# Altium schematic sheet INTERFACE.SchDoc(INTERFACE)
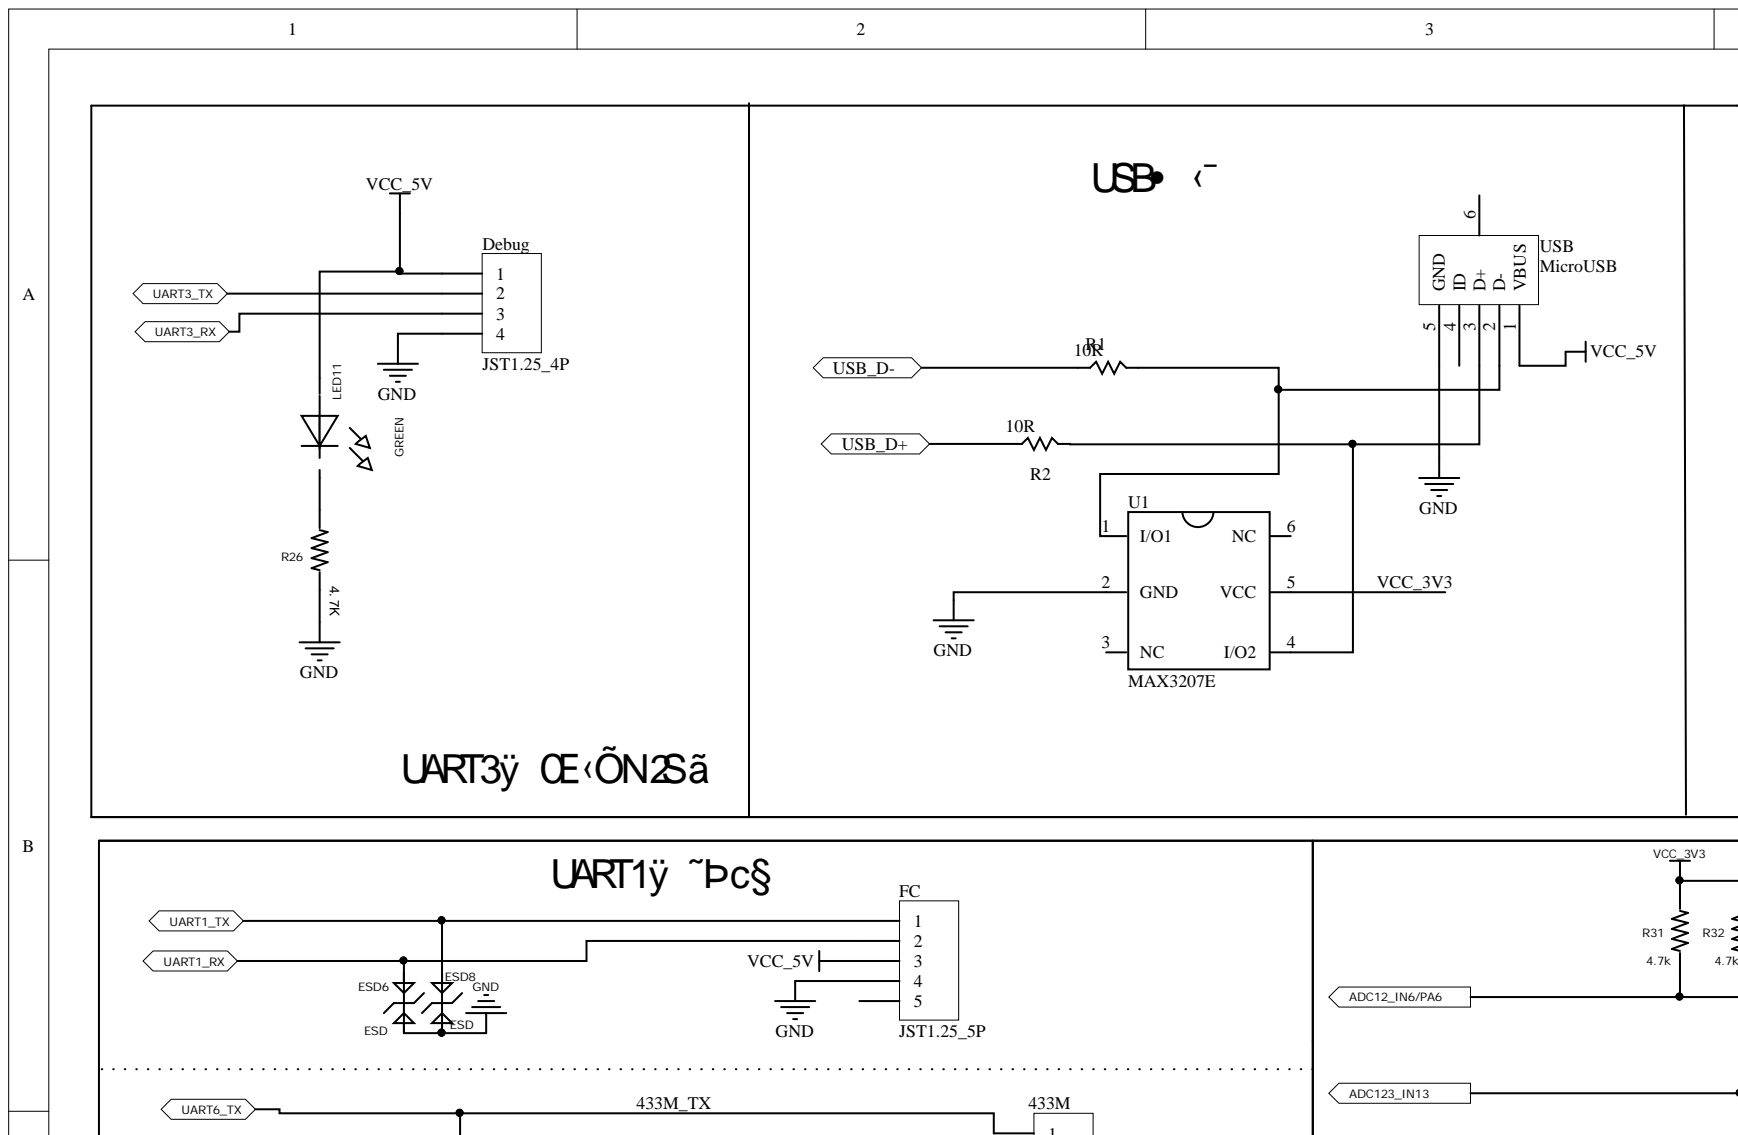
[diagram p0: INTERFACE.SchDoc, top left]
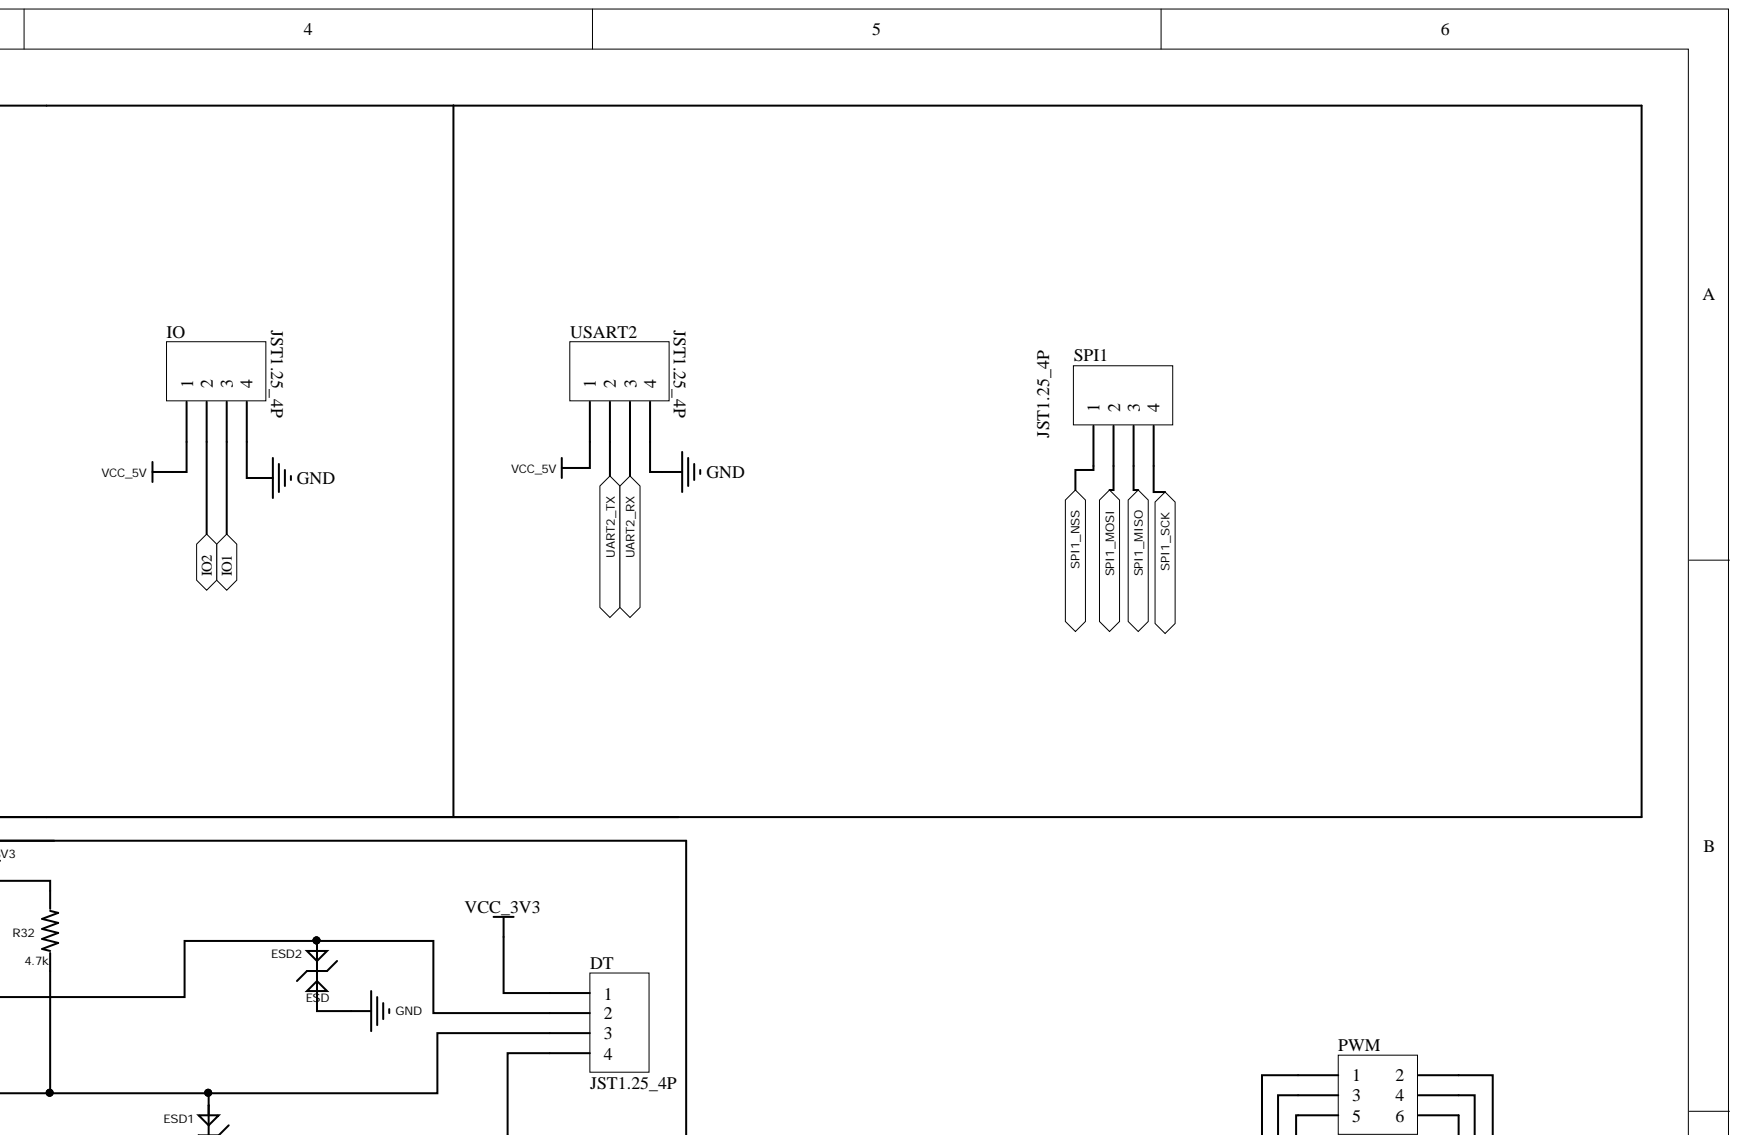
[diagram p1: INTERFACE.SchDoc, top right]
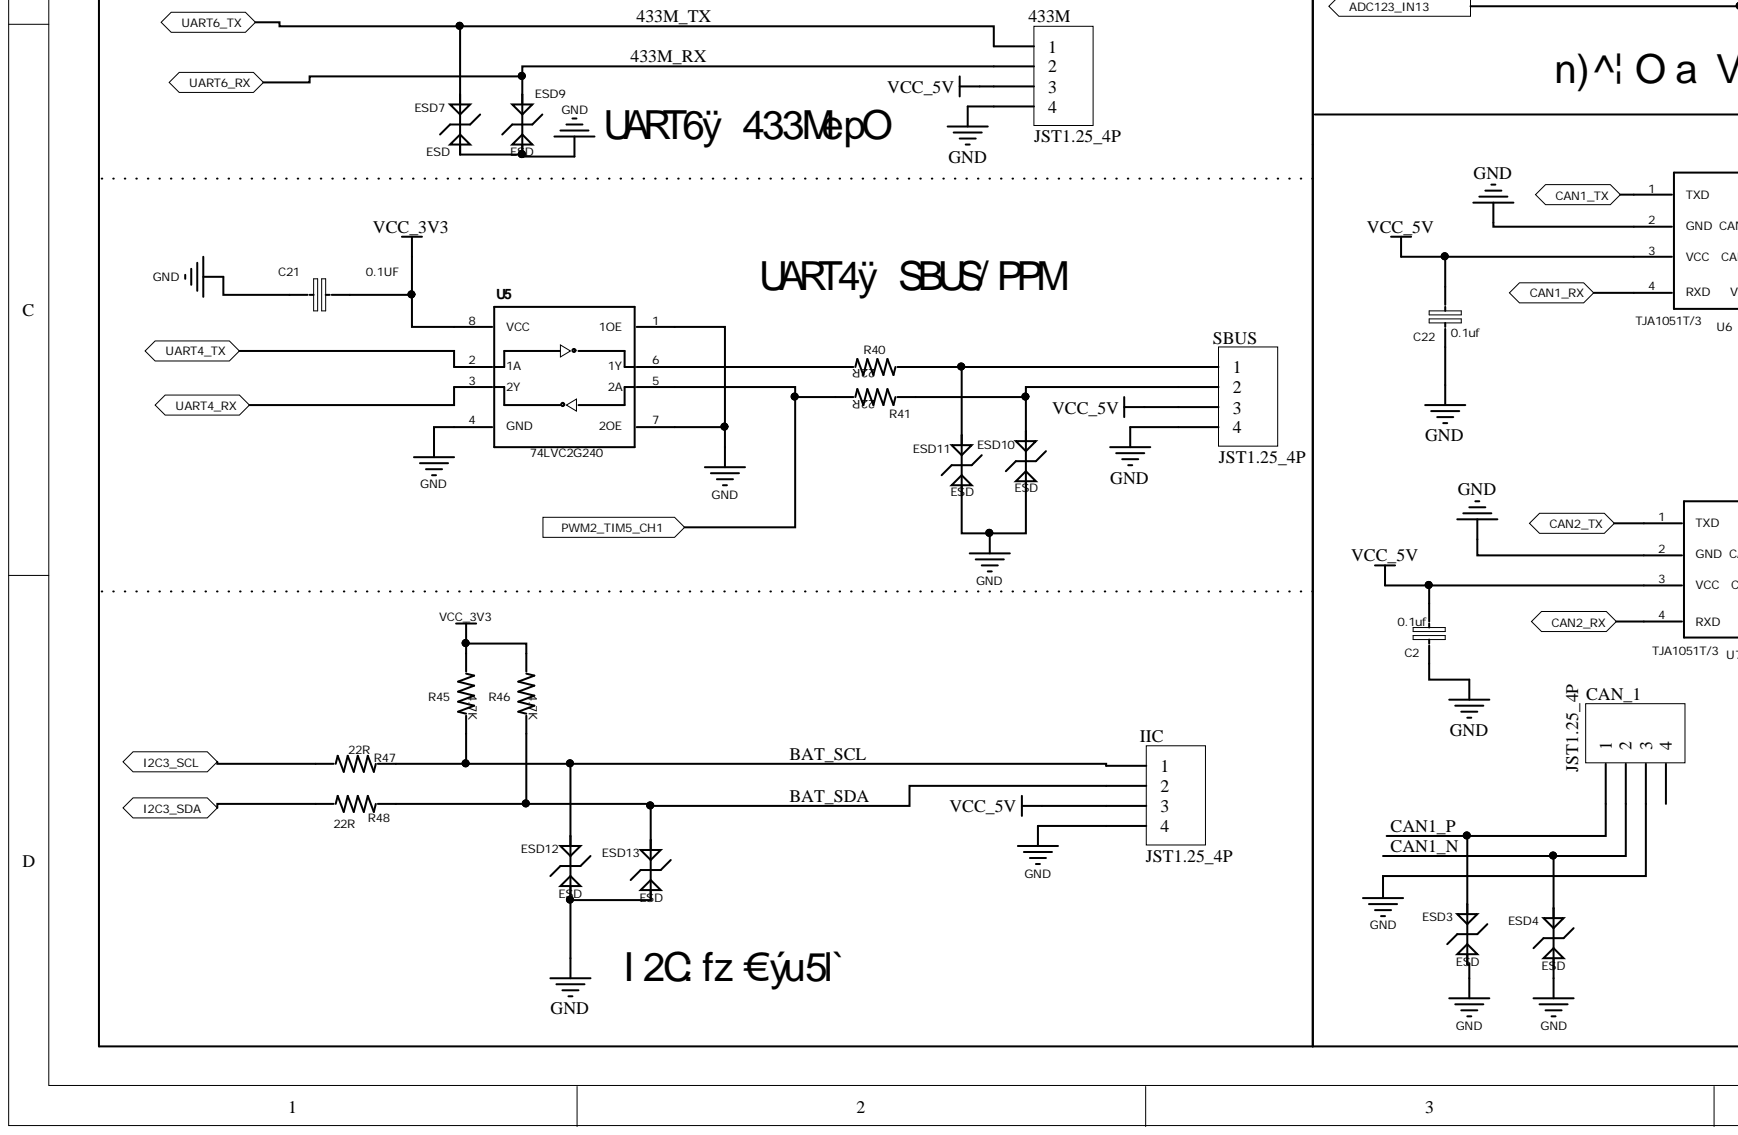
[diagram p2: INTERFACE.SchDoc, bottom left]
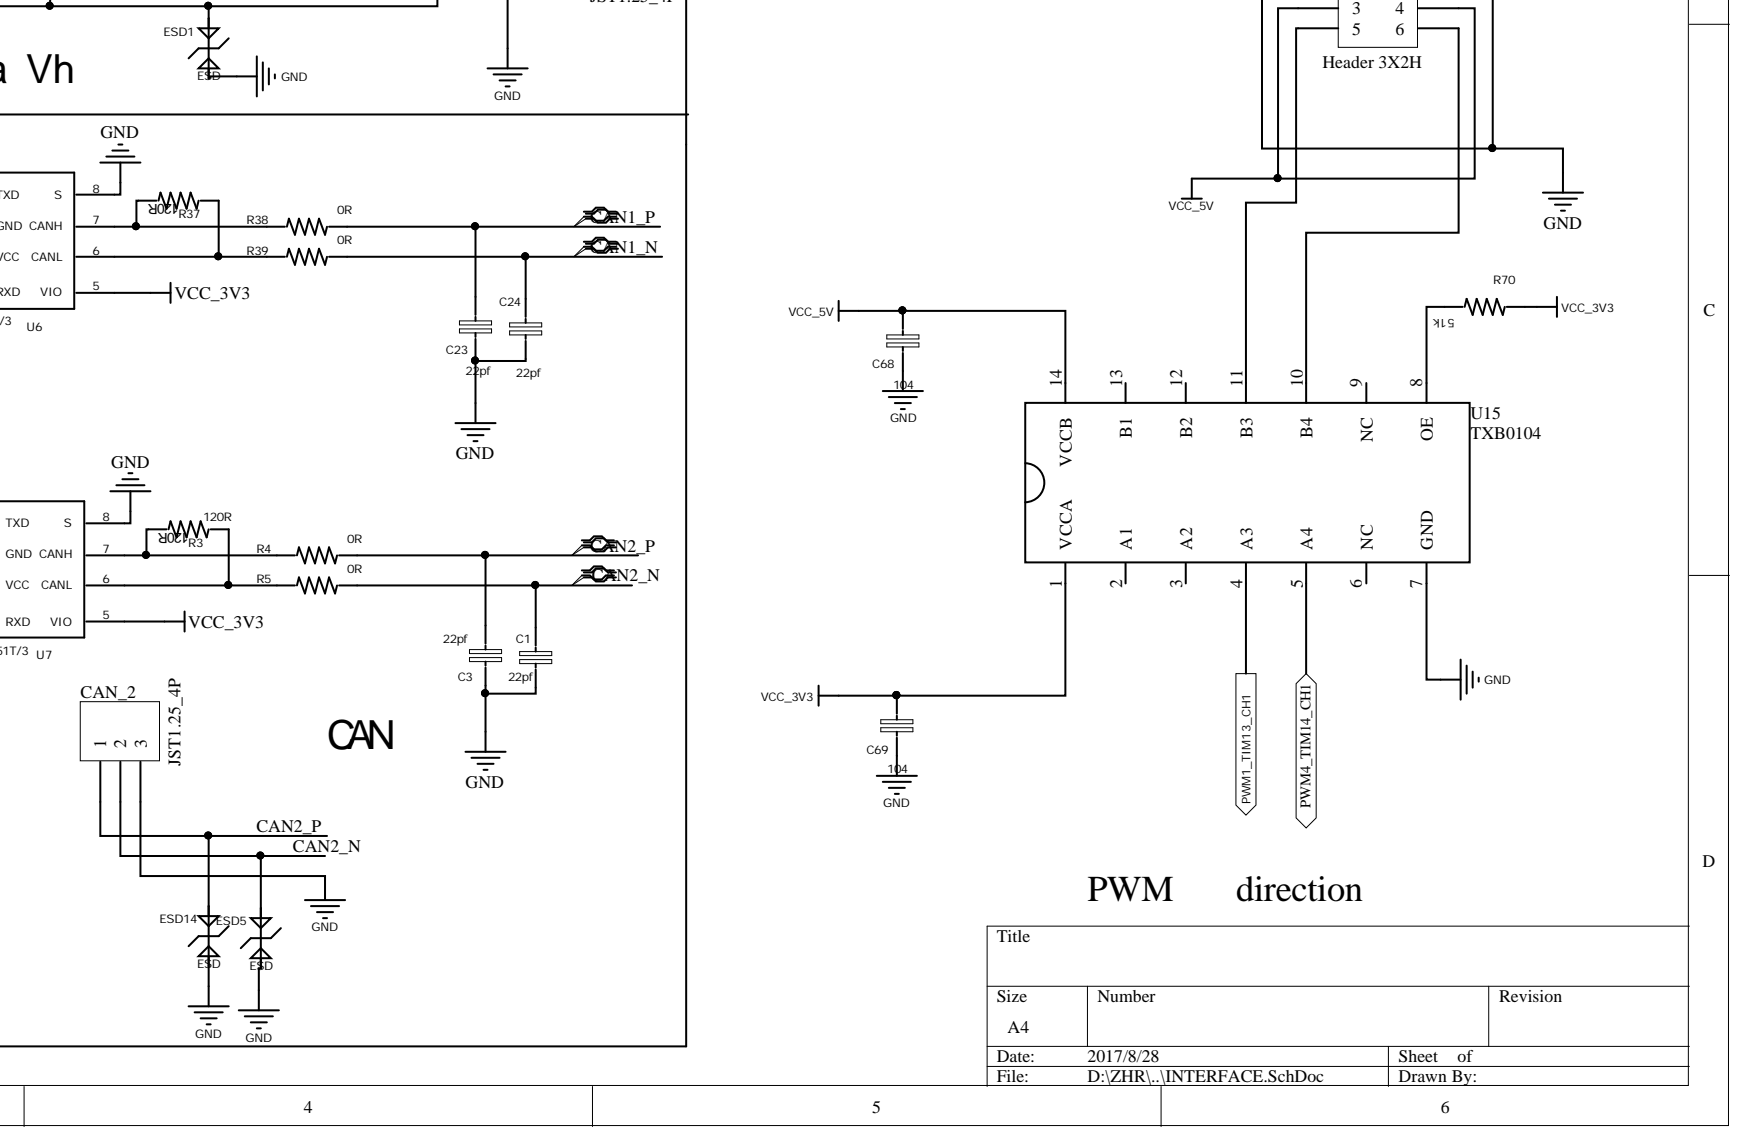
[diagram p3: INTERFACE.SchDoc, bottom right]

## Parts
433M  JST1.25_4P  [JST_4P_1.25_Standard]
C1  22pf  [SC0603]
C2  0.1uf  [SC0603]
C3  22pf  [SC0603]
C21  0.1UF  [SC0603]
C22  0.1uf  [SC0603]
C23  22pf  [SC0603]
C24  22pf  [SC0603]
C68  104  [SC0603]
C69  104  [SC0603]
CAN_1  JST1.25_4P  [JST_4P_1.25_Standard]
CAN_2  JST1.25_4P  [JST_3P_1.25]
Debug  JST1.25_4P  [JST_4P_1.25_Standard]
DT  JST1.25_4P  [JST_4P_1.25_Standard]
ESD1  ESD  [SD-ESD0603]
ESD2  ESD  [SD-ESD0603]
ESD3  ESD  [SD-ESD0603]
ESD4  ESD  [SD-ESD0603]
ESD5  ESD  [SD-ESD0603]
ESD6  ESD  [SD-ESD0603]
ESD7  ESD  [SD-ESD0603]
ESD8  ESD  [SD-ESD0603]
ESD9  ESD  [SD-ESD0603]
ESD10  ESD  [SD-ESD0603]
ESD11  ESD  [SD-ESD0603]
ESD12  ESD  [SD-ESD0603]
ESD13  ESD  [SD-ESD0603]
ESD14  ESD  [SD-ESD0603]
FC  JST1.25_5P  [JST_5P_1.25_Standard]
IIC  JST1.25_4P  [JST_4P_1.25_Standard]
IO  JST1.25_4P  [JST_4P_1.25_Standard]
LED11  LED  value GREEN  [SD0603]
PWM  Header 3X2H  [HDR2X3H]
R1  10R  [SR0603]
R2  10R  [SR0603]
R3  120R  [SR0603]
R4  0R  [SR0603]
R5  0R  [SR0603]
R26  4.7K  [SR0603]
R31  4.7k  [SR0603]
R32  4.7k  [SR0603]
R37  120R  [SR0603]
R38  0R  [SR0603]
R39  0R  [SR0603]
R40  22R  [SR0603]
R41  22R  [SR0603]
R45  4.7K  [SR0603]
R46  4.7K  [SR0603]
R47  22R  [SR0603]
R48  22R  [SR0603]
R70  51k  [SR0603]
SBUS  JST1.25_4P  [JST_4P_1.25_Standard]
SPI1  JST1.25_4P  [JST_4P_1.25_Standard]
U1  MAX3207E  [SOT23-6]
U5  74LVC2G240  [LC-TSSOP-8_3x4.4x065P]
U6  TJA1051T/3  [SOIC127P600X100-8N]
U7  TJA1051T/3  [SOIC127P600X100-8N]
U15  TXB0104  [TSSOP14-TBS]
USART2  JST1.25_4P  [JST_4P_1.25_Standard]
USB  MicroUSB  [MICRO_USB_B]

## Ports
ADC12_IN6/PA6, ADC123_IN13, CAN1_RX, CAN1_TX, CAN2_RX, CAN2_TX, I2C3_SCL, I2C3_SDA, IO1, IO2, PWM1_TIM13_CH1, PWM2_TIM5_CH1, PWM4_TIM14_CH1, SPI1_MISO, SPI1_MOSI, SPI1_NSS, SPI1_SCK, UART1_RX, UART1_TX, UART2_RX, UART2_TX, UART3_RX, UART3_TX, UART4_RX, UART4_TX, UART6_RX, UART6_TX, USB_D+, USB_D-

## Nets
GND: 433M.4 C1.2 C2.1 C3.2 C21.1 C22.1 C23.2 C24.2 C68.2 C69.2 CAN_1.3 CAN_2.3 Debug.4 DT.4 ESD1.1 ESD2.1 ESD3.1 ESD4.1 ESD5.1 ESD6.1 ESD7.1 ESD8.1 ESD9.1 ESD10.1 ESD11.1 ESD12.1 ESD13.1 ESD14.1 FC.4 IIC.4 IO.4 PWM.1 PWM.2 R26.1 SBUS.4 U1.2(GND) U5.1(1OE) U5.4(GND) U5.7(2OE) U6.2(GND) U6.8(S) U7.2(GND) U7.8(S) U15.7(GND) USART2.4 USB.5(GND)
VCC_5V: 433M.3 C2.2 C22.2 C68.1 Debug.1 FC.3 IIC.3 IO.1 LED11.2 PWM.3 PWM.4 SBUS.3 U6.3(VCC) U7.3(VCC) U15.14(VCCB) USART2.1 USB.1(VBUS)
433M_RX: 433M.2 ESD9.2 ; port UART6_RX
433M_TX: 433M.1 ESD7.2 ; port UART6_TX
ADC12_IN6/PA6: DT.2 ESD2.2 R31.1 ; port ADC12_IN6/PA6
ADC123_IN13: DT.3 ESD1.2 R32.1 ; port ADC123_IN13
BAT_SCL: ESD12.2 IIC.1 R45.1 R47.1
BAT_SDA: ESD13.2 IIC.2 R46.1 R48.1
CAN1_N: C24.1 CAN_1.2 ESD4.2 R39.2
CAN1_P: C23.1 CAN_1.1 ESD3.2 R38.2
CAN1_RX: U6.4(RXD) ; port CAN1_RX
CAN1_TX: U6.1(TXD) ; port CAN1_TX
CAN2_N: C1.1 CAN_2.2 ESD5.2 R5.2
CAN2_P: C3.1 CAN_2.1 ESD14.2 R4.2
CAN2_RX: U7.4(RXD) ; port CAN2_RX
CAN2_TX: U7.1(TXD) ; port CAN2_TX
I2C3_SCL: R47.2 ; port I2C3_SCL
I2C3_SDA: R48.2 ; port I2C3_SDA
IO1: IO.3 ; port IO1
IO2: IO.2 ; port IO2
PWM1_TIM13_CH1: U15.4(A3) ; port PWM1_TIM13_CH1
PWM2_TIM5_CH1: R41.2 U5.5(2A) ; port PWM2_TIM5_CH1
PWM4_TIM14_CH1: U15.5(A4) ; port PWM4_TIM14_CH1
SPI1_MISO: SPI1.3 ; port SPI1_MISO
SPI1_MOSI: SPI1.2 ; port SPI1_MOSI
SPI1_NSS: SPI1.1 ; port SPI1_NSS
SPI1_SCK: SPI1.4 ; port SPI1_SCK
UART1_RX: ESD6.2 FC.2 ; port UART1_RX
UART1_TX: ESD8.2 FC.1 ; port UART1_TX
UART2_RX: USART2.3 ; port UART2_RX
UART2_TX: USART2.2 ; port UART2_TX
UART3_RX: Debug.3 ; port UART3_RX
UART3_TX: Debug.2 ; port UART3_TX
UART4_RX: U5.3(2Y) ; port UART4_RX
UART4_TX: U5.2(1A) ; port UART4_TX
VCC_3V3: C21.2 C69.1 DT.1 R31.2 R32.2 R45.2 R46.2 R70.1 U1.5(VCC) U5.8(VCC) U6.5(VIO) U7.5(VIO) U15.1(VCCA)
NetESD10_2: ESD10.2 R41.1 SBUS.2
NetESD11_2: ESD11.2 R40.1 SBUS.1
NetLED11_1: LED11.1 R26.2
NetPWM_5: PWM.5 U15.11(B3)
NetPWM_6: PWM.6 U15.10(B4)
NetR1_1: R1.1
NetR1_2: R1.2 U1.1(I/O1) USB.2(D-)
NetR2_1: R2.1
NetR2_2: R2.2 U1.4(I/O2) USB.3(D+)
NetR3_1: R3.1 R5.1 U7.6(CANL)
NetR3_2: R3.2 R4.1 U7.7(CANH)
NetR37_1: R37.1 R39.1 U6.6(CANL)
NetR37_2: R37.2 R38.1 U6.7(CANH)
NetR40_2: R40.2 U5.6(1Y)
NetR70_2: R70.2 U15.8(OE)

Not connected: CAN_1 4; FC 5; U1 3(NC) 6(NC); U15 2(A1) 3(A2) 6(NC) 9(NC) 12(B2) 13(B1); USB 4(ID) 6

## Library data of the parts
433M CAN_1 Debug DT IIC IO SBUS SPI1 USART2 = Header 4: Header, 4-Pin; Library Name: Miscellaneous Connectors.IntLib; LatestRevisionNote: Re-released for DXP Platform.; LatestRevisionDate: 17-Jul-2002; Component Kind: Standard; Publisher: Altium Limited
  433M CAN_1 DT IIC IO SBUS SPI1 USART2: Signal Integrity: Connector
C1 C3 C21 C23 C24 C68 C69 = CAP0603: PCB DECAL: SC0603; Component Kind: Standard
  C1 C3 C23 C24: Value: 22pF
  C21: Value: 0.1uF
  C68 C69: Value: 104
C2 C22 = CAP0402-CAP: Value: 0.1uF; PCB DECAL: SC0603; Component Kind: Standard
CAN_2 = Header 3: Header, 3-Pin; Signal Integrity: Connector; Library Name: Miscellaneous Connectors.IntLib; LatestRevisionNote: Re-released for DXP Platform.; LatestRevisionDate: 17-Jul-2002; Component Kind: Standard; Publisher: Altium Limited
ESD1-ESD14 = ESD0603: Value: ESD; PCB DECAL: SD-ESD0603; Component Kind: Standard
FC = Header 5: Header, 5-Pin; Signal Integrity: Connector; Library Name: Miscellaneous Connectors.IntLib; LatestRevisionNote: Re-released for DXP Platform.; LatestRevisionDate: 17-Jul-2002; Component Kind: Standard; Publisher: Altium Limited
PWM = Header 3X2H: Header, 3-Pin, Dual row, Right Angle; Signal Integrity: Connector; Library Name: Miscellaneous Connectors.IntLib; LatestRevisionNote: Re-released for DXP Platform.; LatestRevisionDate: 17-Jul-2002; Component Kind: Standard; Publisher: Altium Limited
R1 R2 = R0603: Component Kind: Standard; : 10R; Library Name: 电阻库.Schlib
R3 R26 R37 R40 R41 R47 R48 = RESISTOR0402-RES: PCB DECAL: SR0603; Component Kind: Standard
  R3 R37: Value: 120R
  R26: Value: 4.7K
  R40 R41 R47 R48: Value: 22R
R4 R5 R38 R39 R70 = RESL0603: PCB DECAL: SR0603; Component Kind: Standard
  R4 R5 R38 R39: Value: 0R
  R70: Value: 51k
R31 R32 R45 R46 = RESISTOR0402-RES: Value: 4K7; PCB DECAL: SR0603; Component Kind: Standard
U5 = 74LVC2G240-TSSOP-8: PCB DECAL: TSSOP8_SOT505-2; Value: 74LVC2G240-TSSOP-8; Component Kind: Standard
LED11 = LED: PCB DECAL: SD0603; Component Kind: Standard
U1 = MAX3207E: Component Kind: Standard; Library Name: 消防无人机主模块VR2.0-20160801.SCHLIB
U6 U7 = TJA1051T/3: Value: TJA1051T/3; PCB DECAL: SOIC127P600X100-8N; Component Kind: Standard
U15 = TXB0104: Component Kind: Standard; Library Name: 消防无人机主模块VR2.0-20160801.SCHLIB
USB = MicroUSB: Component Kind: Standard; Library Name: Harry_SCH_lib.SchLib
